# Altium schematic sheet WATCHDOG.SchDoc(WATCHDOG)
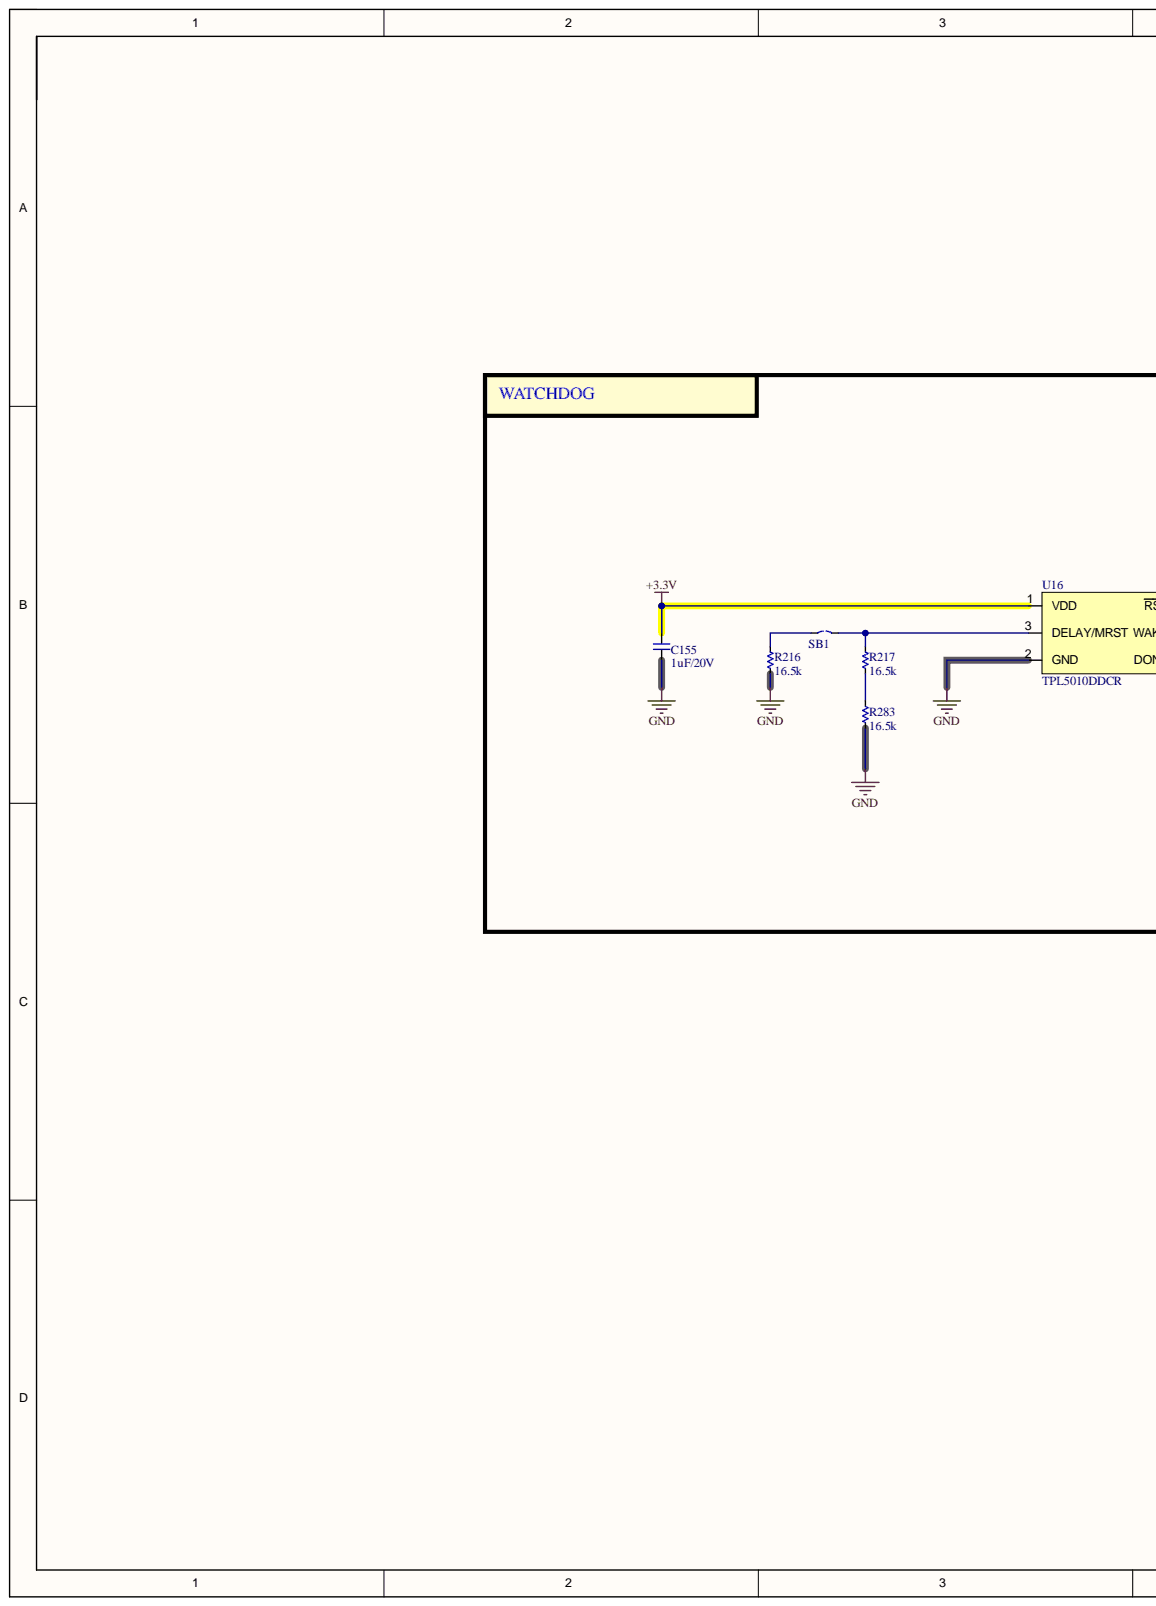
[diagram p0: WATCHDOG.SchDoc, left]
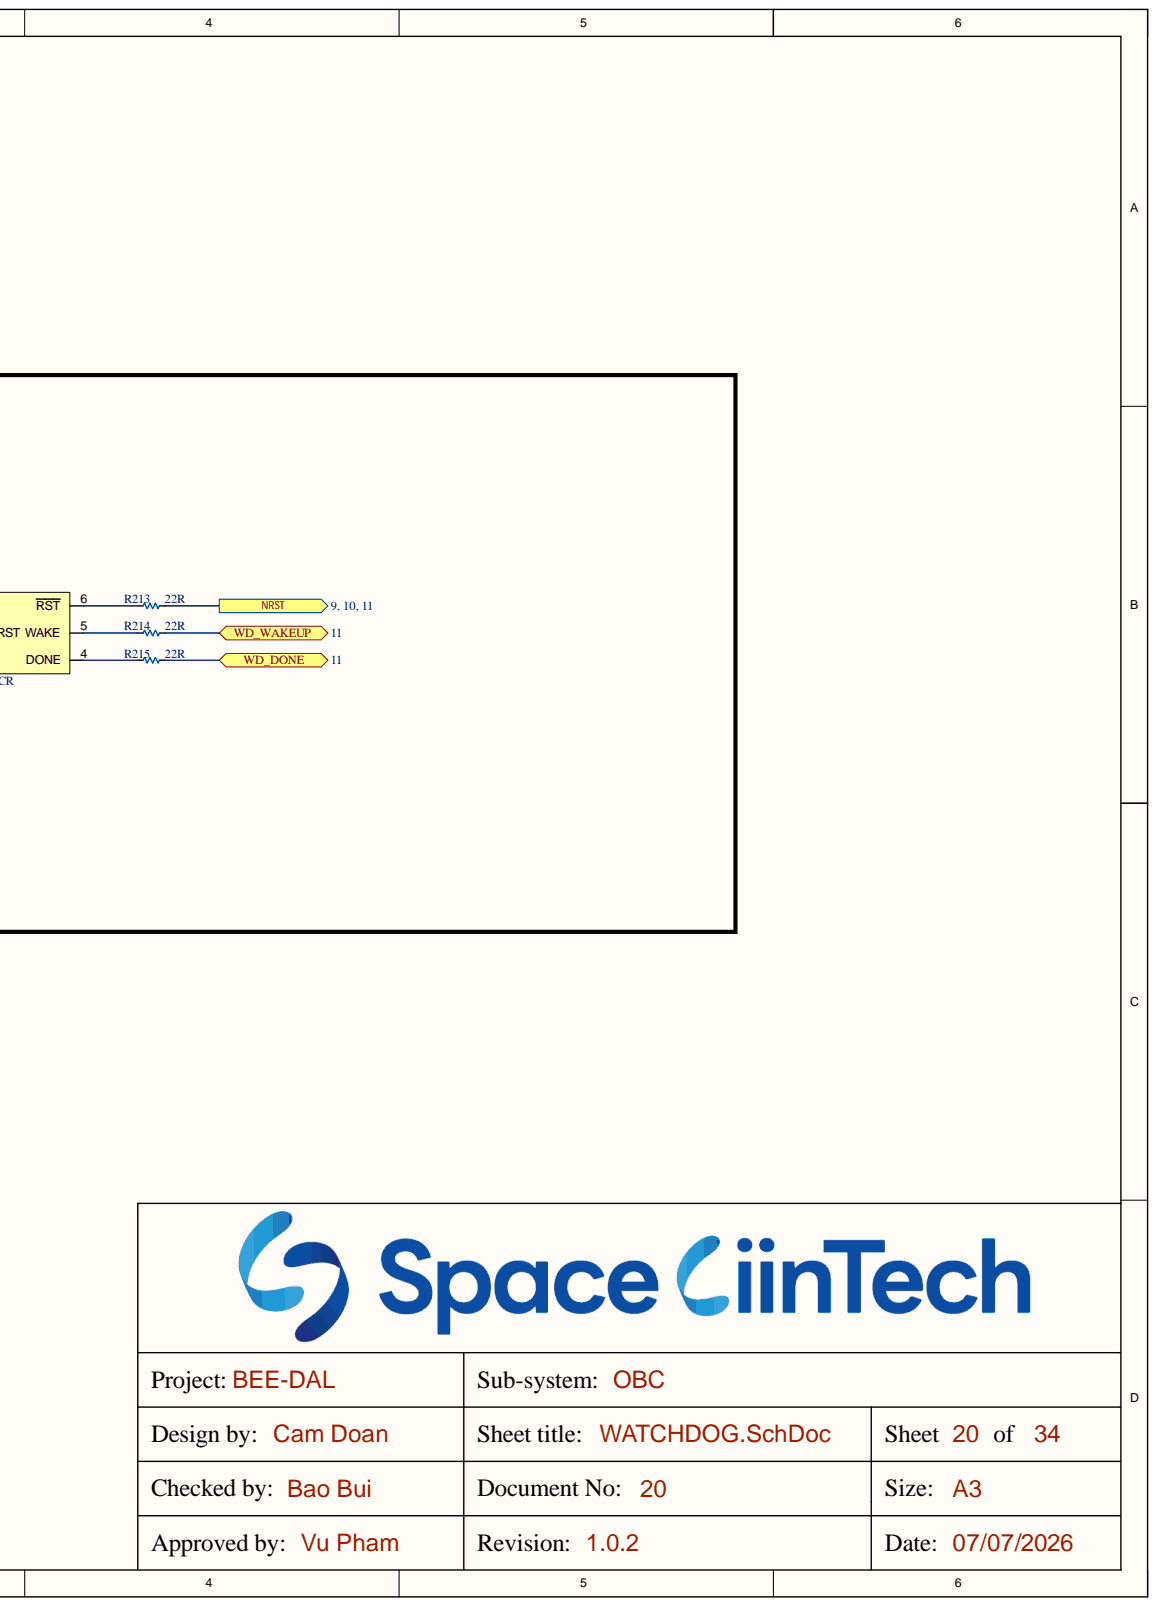
[diagram p1: WATCHDOG.SchDoc, right]

## Parts
C155  1uF/20V  [CAP_C_1005X50_L]
R213  22R  [RES_C_1005x30_L]
R214  22R  [RES_C_1005x30_L]
R215  22R  [RES_C_1005x30_L]
R216  16.5k  [RES_C_1005x30_L]
R217  16.5k  [RES_C_1005x30_L]
R283  16.5k  [RES_C_1005x30_L]
SB1  JUMPER  [JUMPER_1608]  (value not drawn)
U16  TPL5010DDCR  [TPL5010DDCR]

## Ports
NRST, WD_DONE, WD_WAKEUP

## Nets
+3.3V: C155.2 U16.1(VDD)
GND: C155.1 R216.2 R283.2 U16.2(GND)
NRST: R213.2 ; port NRST
WD_DONE: R215.2 ; port WD_DONE
WD_WAKEUP: R214.2 ; port WD_WAKEUP
NetR213_1: R213.1 U16.6(RST)
NetR214_1: R214.1 U16.5(WAKE)
NetR215_1: R215.1 U16.4(DONE)
NetR216_1: R216.1 SB1.1
NetR217_1: R217.1 SB1.2 U16.3(DELAY/MRST)
NetR217_2: R217.2 R283.1

## Library data of the parts
C155 = _CAP_C_2: CAP CHIP; Component Kind: Standard; Library Name: IFBschelib.SchLib
R213-R217 R283 = _RES_B_2: RES; Component Kind: Standard
  R213-R215: Library Name: LABLIB.SchLib
  R216 R217 R283: Library Name: IFBschelib.SchLib
U16 = TPL5010DDCR: OSC PROG TIMER TSOT23-6; Component Kind: Standard; Library Name: IFBschelib.SchLib
SB1 = JUMPER: Component Kind: Standard; Library Name: IFBschelib.SchLib
